# Altium schematic sheet Buzzer.SchDoc(Buzzer)
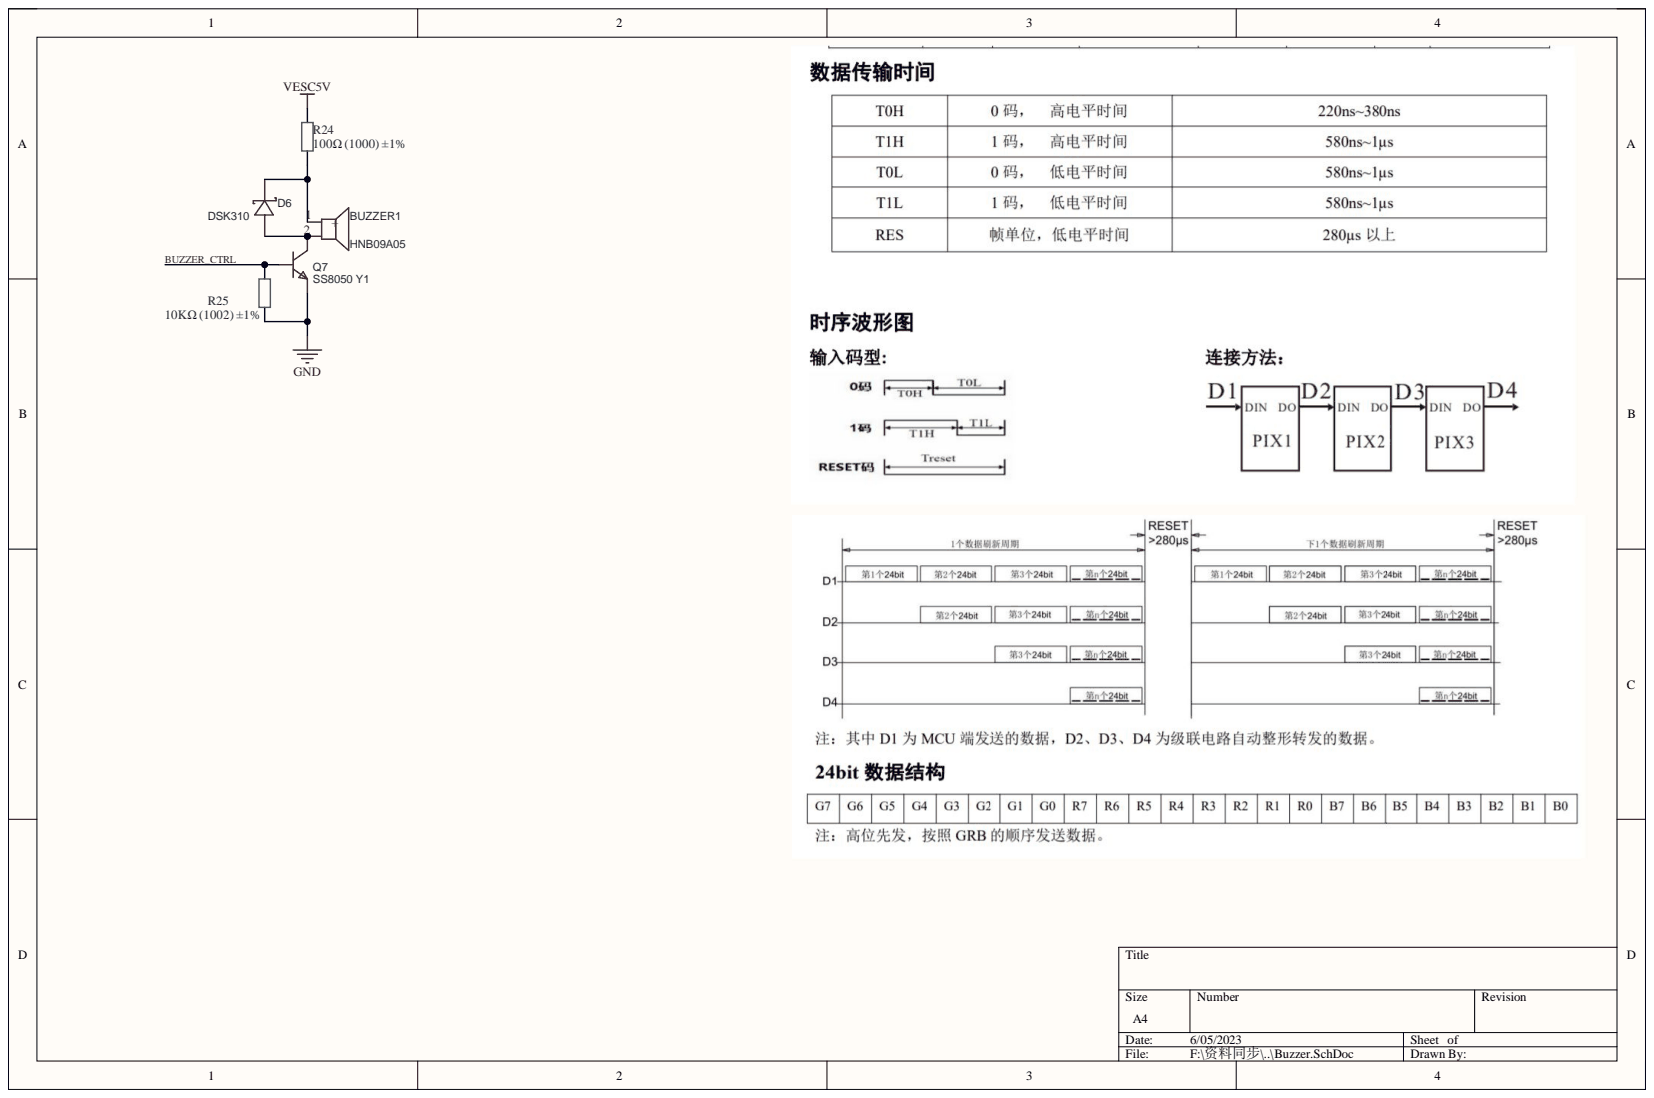
[diagram p0: Buzzer.SchDoc]

## Parts
BUZZER1  HNB09A05  [BUZ-TH_BD9.6-P5.00-D0.7-FD]
D6  DSK310  [SOD-123_L2.7-W1.8-LS3.7-RD]
Q7  SS8050 Y1  [SOT-23-3_L2.9-W1.3-P1.90-LS2.4-BR]
R24  100Ω (1000) ±1%  [0805_R]
R25  10KΩ (1002) ±1%  [0402]

## Nets
GND: Q7.2 R25.2
VESC5V: R24.2
BUZZER_CTRL: Q7.1 R25.1
NetBUZZER1_1: BUZZER1.1 D6.1 R24.1
NetBUZZER1_2: BUZZER1.2 D6.2 Q7.3

## Library data of the parts
BUZZER1 = HNB09A05: BOM_Manufacturer: HNDZ; BOM_Manufacturer Part: HNB09A05; BOM_Supplier: LCSC; BOM_Supplier Part: C96493; Component Kind: Standard; spicePre: B; spiceSymbolName: HNB09A05
D6 = DSK310: BOM_Manufacturer: MDD; Contributor: LCSC; BOM_JLCPCB Part Class: Extended Part; BOM_Manufacturer Part: DSK310; BOM_Supplier: LCSC; BOM_Supplier Part: C106914; Component Kind: Standard; spicePre: D; spiceSymbolName: DSK310
Q7 = S8050_C2894711: BOM_Manufacturer: PUOLOP(迪浦); BOM_Manufacturer Part: S8050; Contributor: LCSC; BOM_Supplier: LCSC; BOM_Supplier Part: C2894711; Component Kind: Standard; spicePre: Q; spiceSymbolName: S8050_C2894711
R24 = 0805 100Ω (1000) 1%: 贴片电阻; Component Kind: Standard; ComponentLink1Description: 供应商链接; ComponentLink1URL: http://www.szlcsc.com/product/details_18096.html; Library Name: RES.SchLib; Package: 0805; Supplier: LC; SuppliersPartNumber: C17408
R25 = 0603 10KΩ (1002) 1%: 贴片电阻; Component Kind: Standard; ComponentLink1Description: 供应商链接; ComponentLink1URL: http://www.szlcsc.com/product/details_26547.html; Library Name: Miscellaneous Devices LC.IntLib; Package: 0603; Supplier: LC; SuppliersPartNumber: C25804
